# Altium schematic sheet 05_Radio.SchDoc
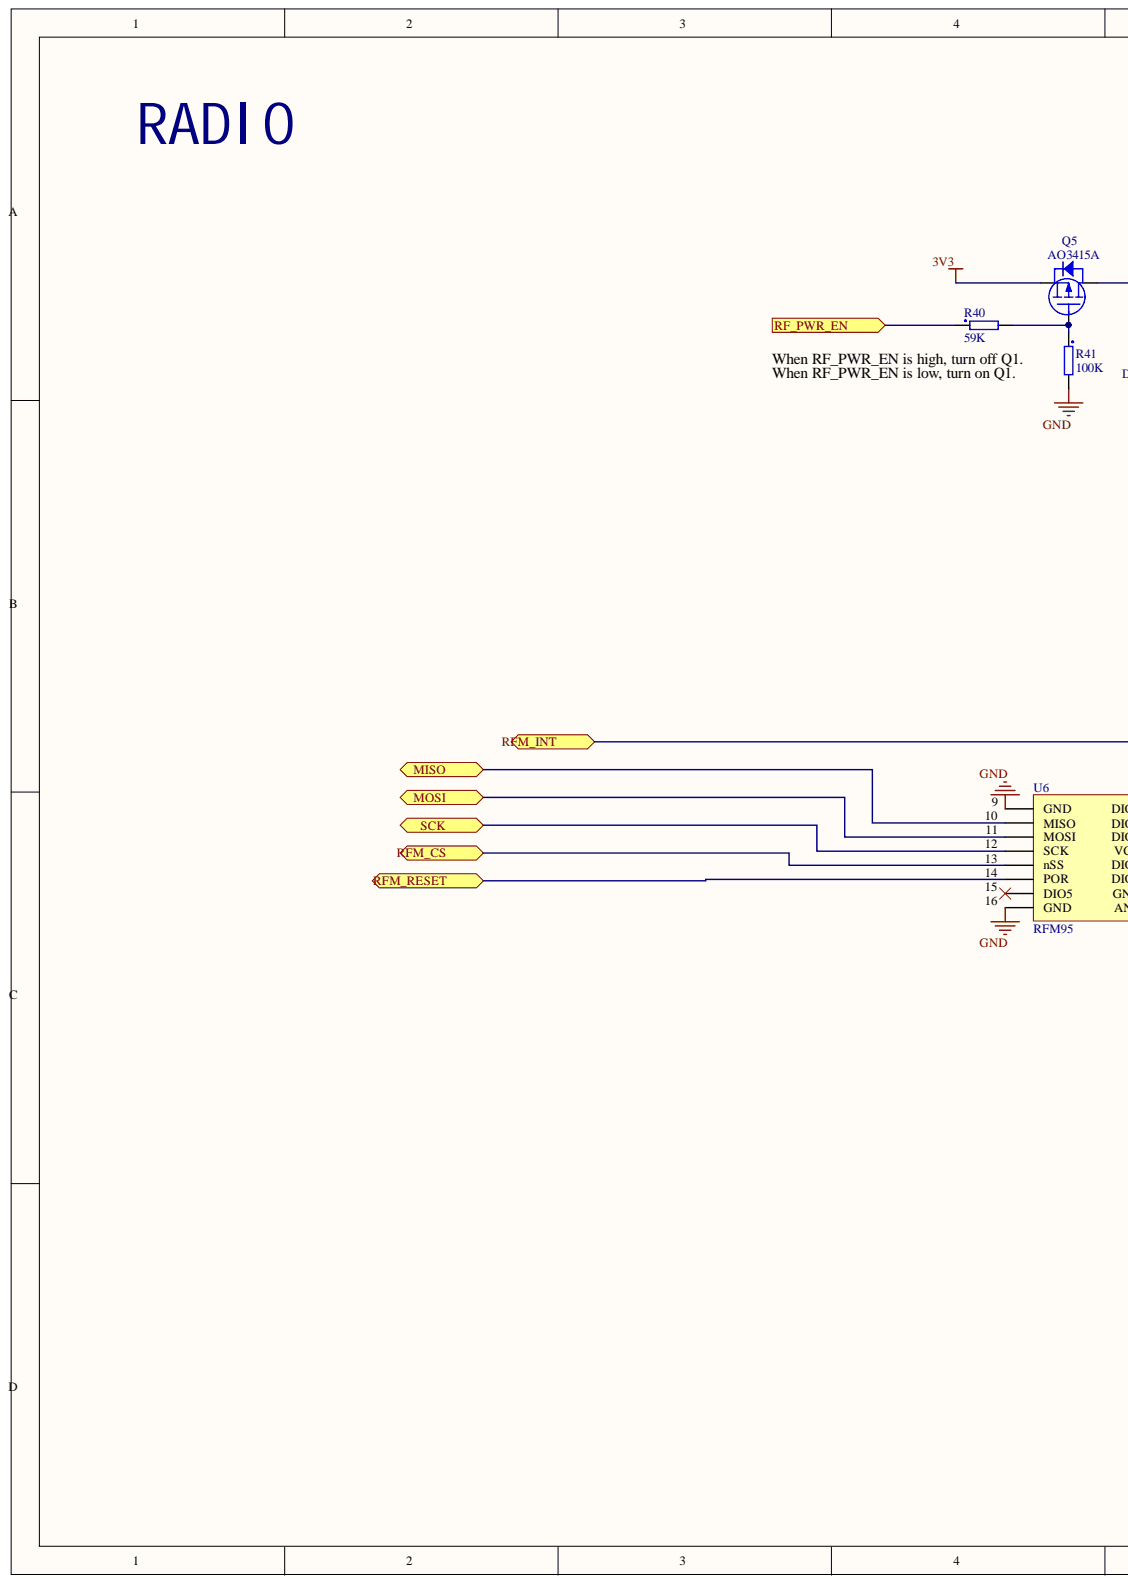
[diagram p0: 05_Radio.SchDoc, left]
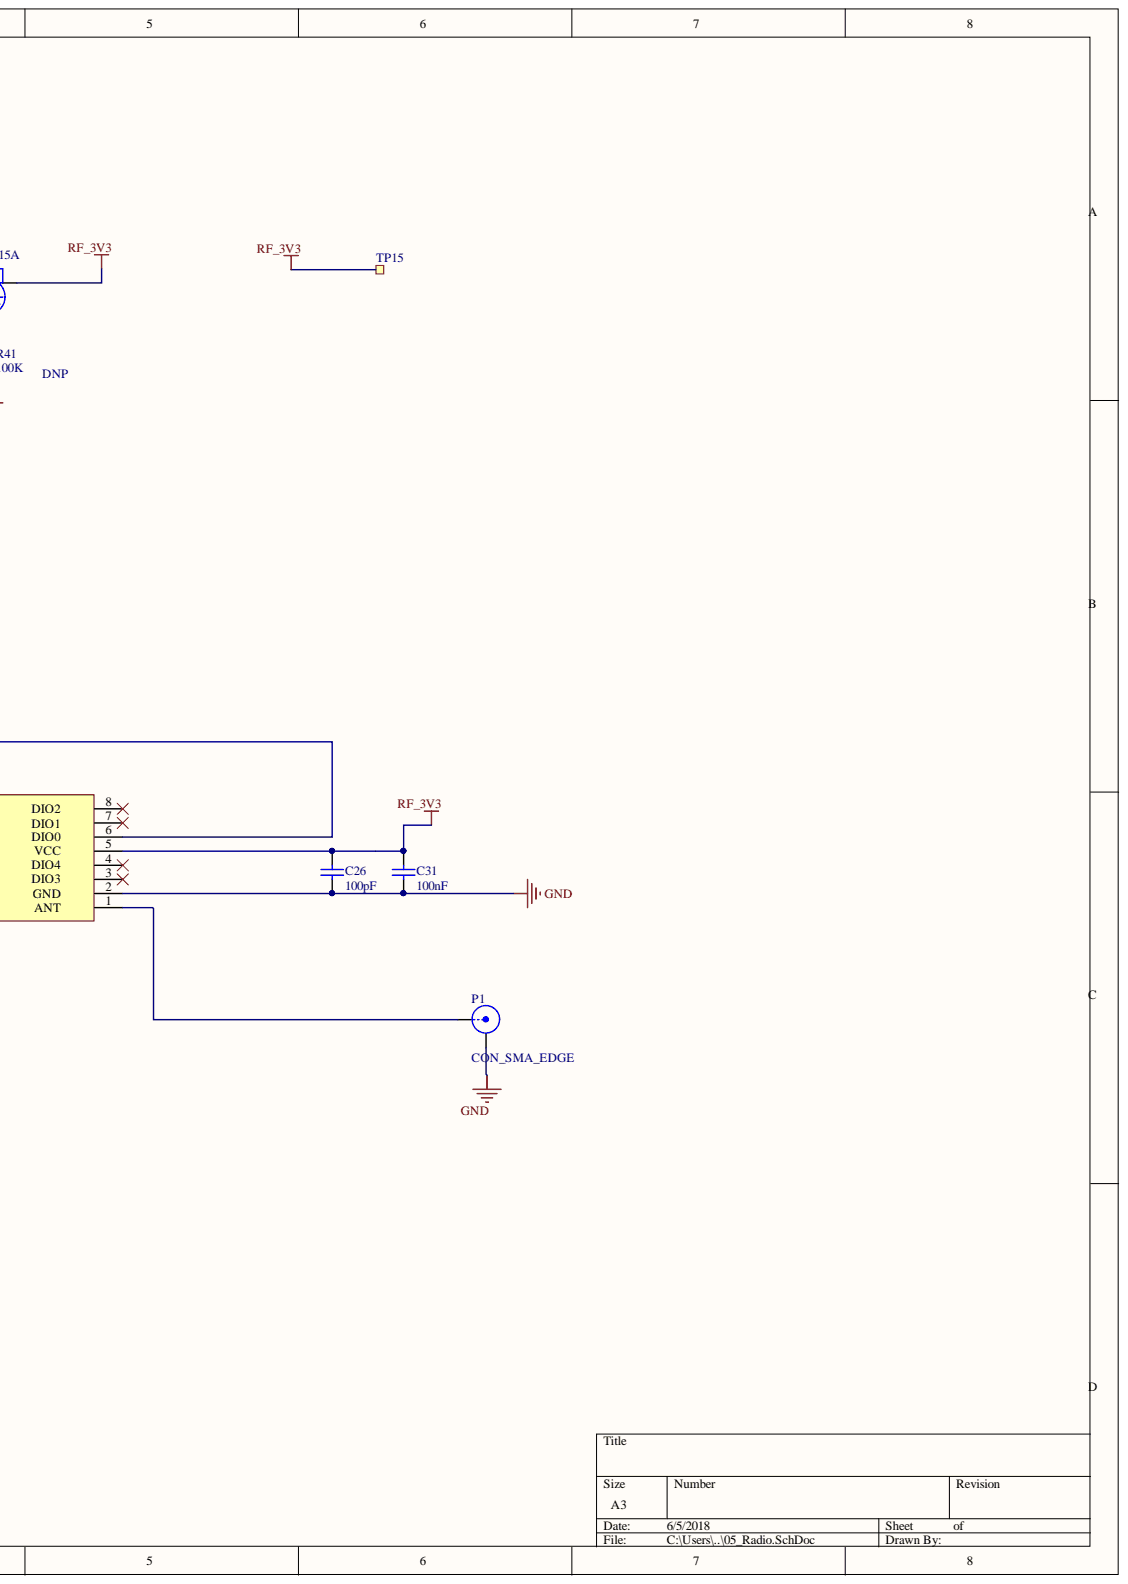
[diagram p1: 05_Radio.SchDoc, right]

## Parts
C26  100pF  [CAP_0603_SMD]
C31  100nF  [CAP_0603_SMD]
P1  CON_SMA_EDGE  [SMA END LAUNCH JACK RECEPTACLE SHORT]
Q5  AO3415A  [SOT23-D]
R40  59K  [RES_0603_smd]
R41  100K  [RES_0603_smd]
TP15  TP  [TP_1mm]  (no BOM)
U6  RFM95  [RFM95]

## Ports
MISO, MOSI, RF_PWR_EN, RFM_CS, RFM_INT, RFM_RESET, SCK

## Nets
3V3: Q5.3
GND: C26.1 C31.1 P1.2 R41.2 U6.2 U6.9(GND) U6.16(GND)
RF_3V3: C26.2 C31.2 Q5.2 TP15.1 U6.5
MISO: U6.10(MISO) ; port MISO
MOSI: U6.11(MOSI) ; port MOSI
RF_PWR_EN: R40.1 ; port RF_PWR_EN
RFM_CS: U6.13(nSS) ; port RFM_CS
RFM_INT: U6.6 ; port RFM_INT
RFM_RESET: U6.14(POR) ; port RFM_RESET
SCK: U6.12(SCK) ; port SCK
NetP1_1: P1.1 U6.1
NetQ5_1: Q5.1 R40.2 R41.1

Not connected: U6 3 4 7 8 15(DIO5)

## Library data of the parts
C26 C31 = Cap: Capacitor; Component Kind: Standard; LatestRevisionDate: 17-Jul-2002; LatestRevisionNote: Re-released for DXP Platform.; Library Name: Miscellaneous Devices.IntLib; PackageDescription: Radial Cap, Thru-Hole; 2 Leads; 0.3 in Pin Spacing; PackageReference: RAD-0.3; Published: 8-Jun-2000; Signal Integrity: Cap; Simulation: CAP; Publisher: Altium Limited
  C26: Value: 100pF
  C31: Value: 100nF
P1 = SMB: SMB Straight Connector; Component Kind: Standard; LatestRevisionDate: 17-Jul-2002; LatestRevisionNote: Re-released for DXP Platform.; Library Name: Miscellaneous Connectors.IntLib; Signal Integrity: Connector; Publisher: Altium Limited
Q5 = MOS-P-D: Component Kind: Standard; Library Name: M46_SCHLIB.SchLib
R40 R41 = RES: Resistor; Component Kind: Standard; Library Name: M46_SCHLIB.SchLib
TP15 = Testpoint TH: Testpoint, through hole; Artikkelnr Noca: N.A.; Artikkelnr Simpro: N.A.; Footprint Doc: TESTPAD-TH; LatestRevisionDate: 2009-12-12; Library Name: pca10056.IntLib; Part Number: Testpoint TH; Pin Count: 1; Published: 2009-12-12; Value: TP; Publisher: Nordic Semiconductor
U6 = RFM95: Component Kind: Standard; Library Name: OutSetDevKitv0.1.SchLib
